# Altium schematic sheet CPU - POWER.SchDoc
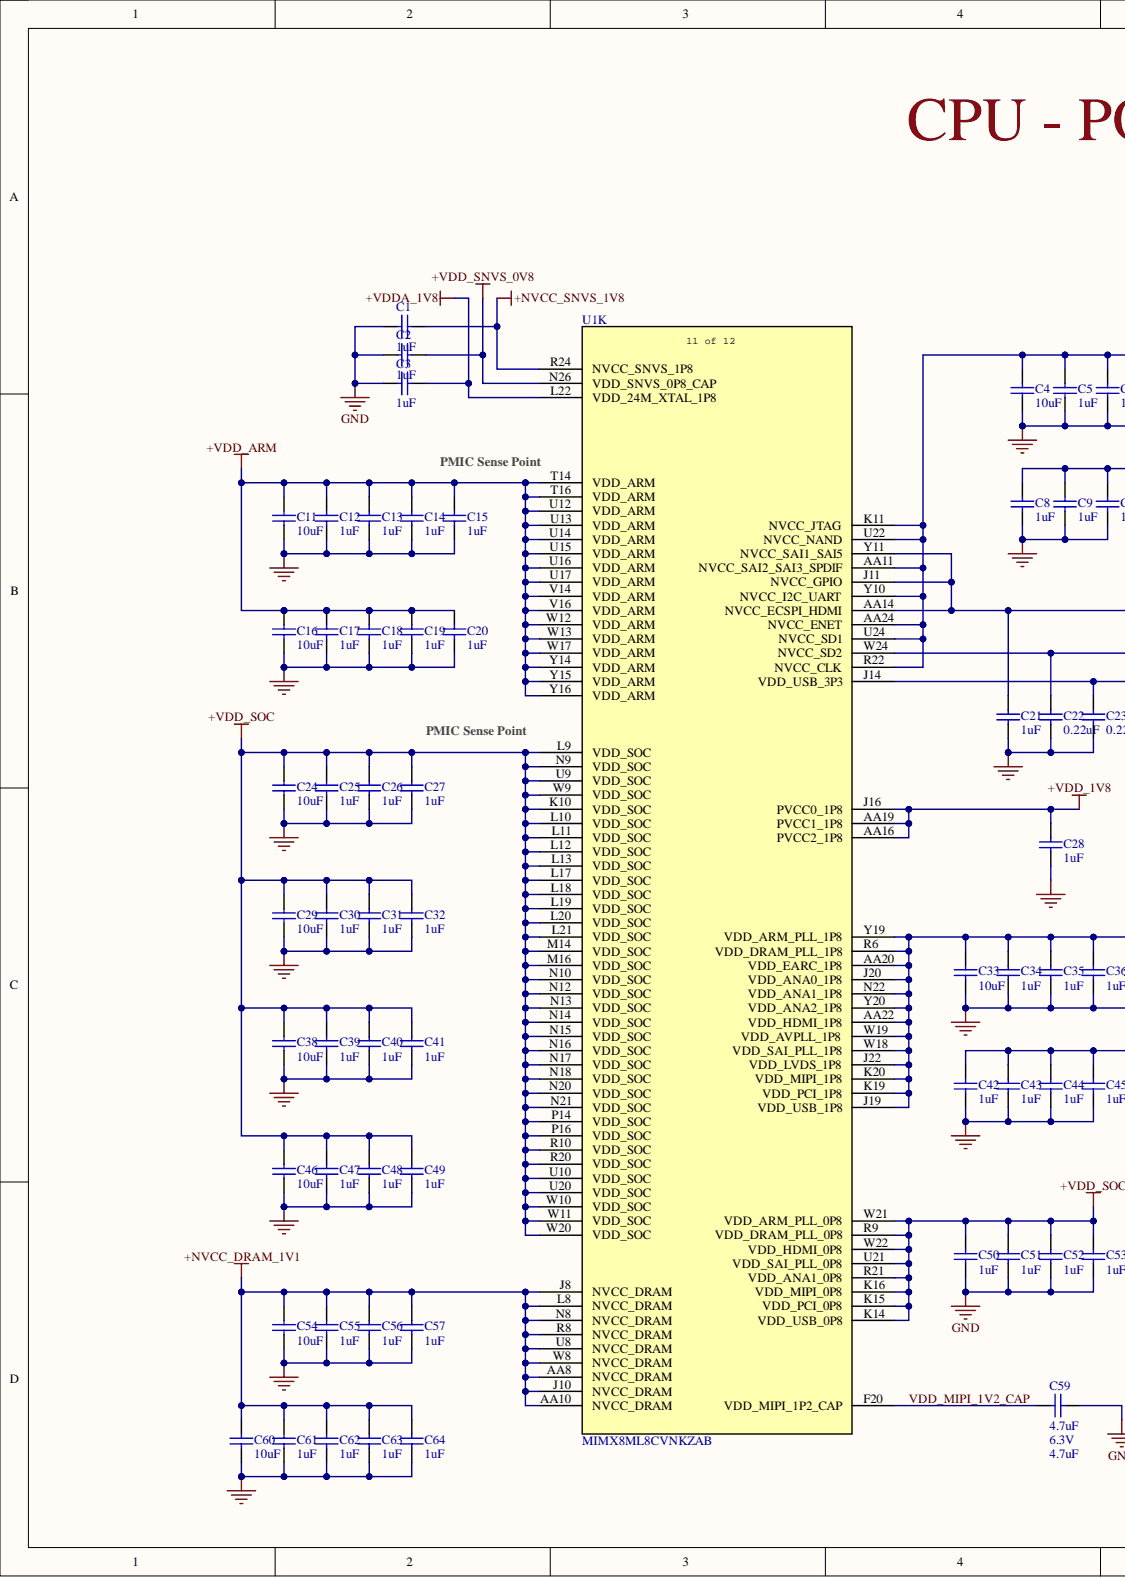
[diagram p0: CPU - POWER.SchDoc, left]
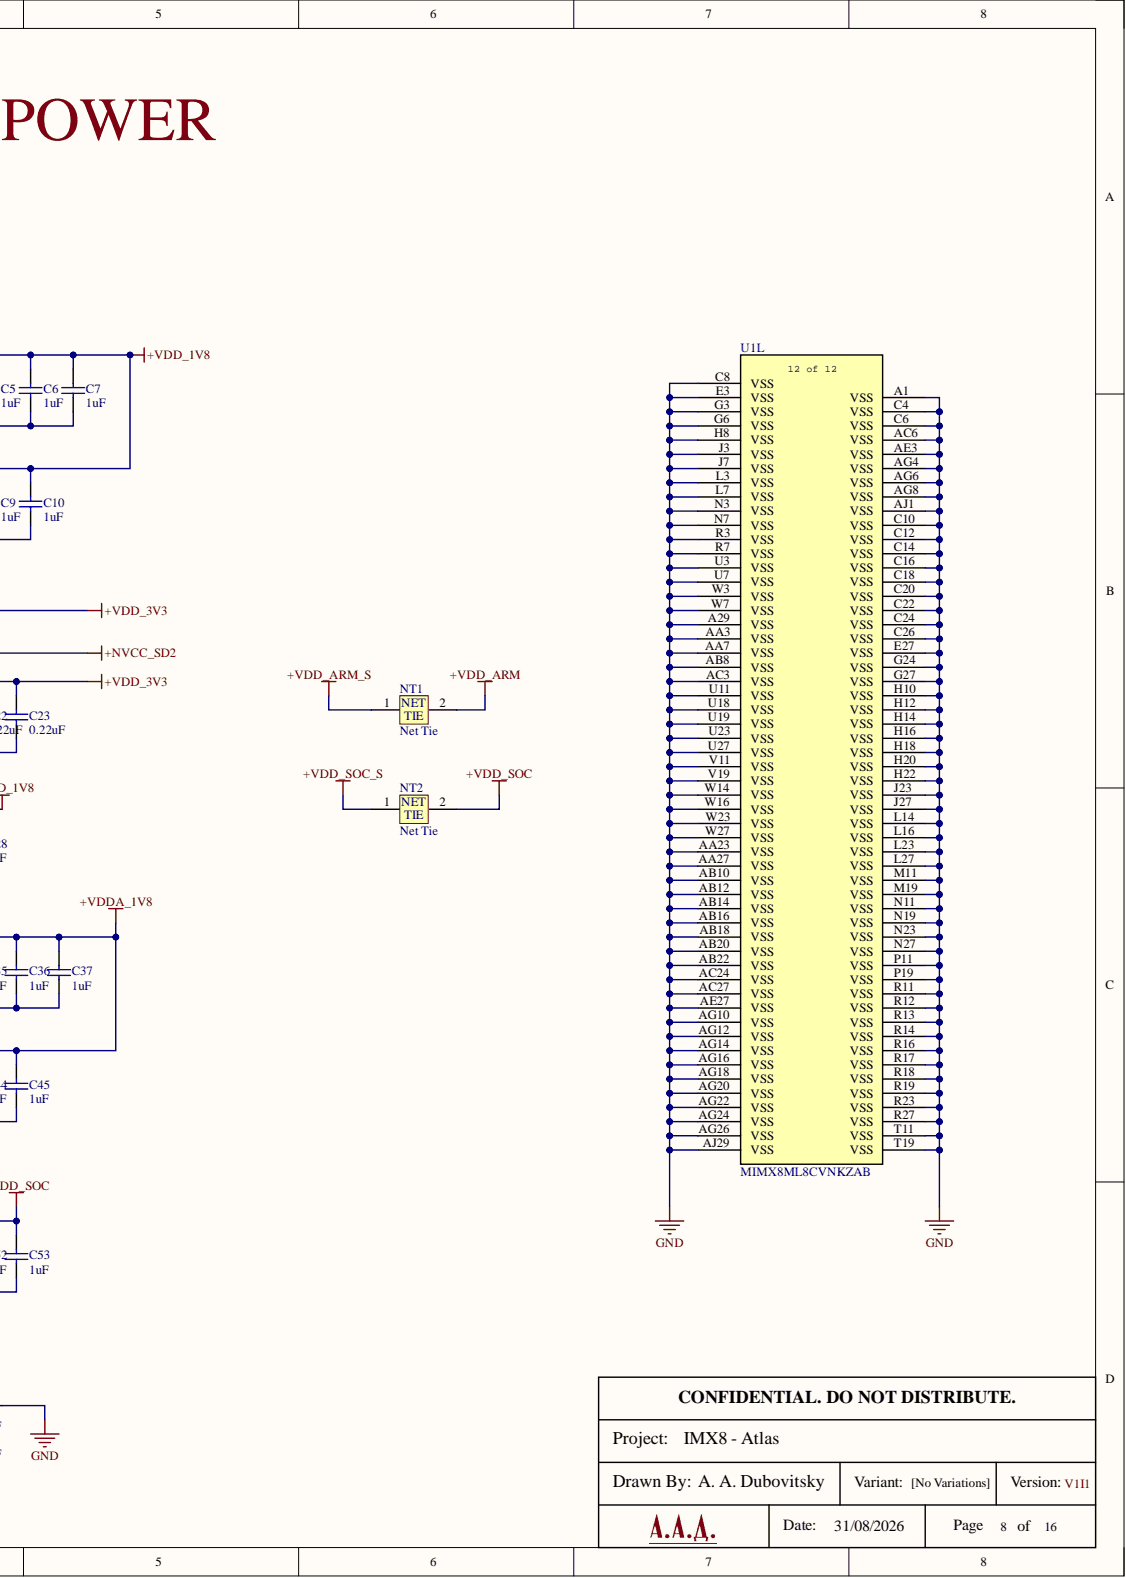
[diagram p1: CPU - POWER.SchDoc, right]

## Parts
C1  1uF  [CAP 0201_0603 MINI]
C2  1uF  [CAP 0201_0603 MINI]
C3  1uF  [CAP 0201_0603 MINI]
C4  10uF  [CAP 0402_1005]
C5  1uF  [CAP 0201_0603 MINI]
C6  1uF  [CAP 0201_0603 MINI]
C7  1uF  [CAP 0201_0603 MINI]
C8  1uF  [CAP 0201_0603 MINI]
C9  1uF  [CAP 0201_0603 MINI]
C10  1uF  [CAP 0201_0603 MINI]
C11  10uF  [CAP 0402_1005]
C12  1uF  [CAP 0201_0603 MINI]
C13  1uF  [CAP 0201_0603 MINI]
C14  1uF  [CAP 0201_0603 MINI]
C15  1uF  [CAP 0201_0603 MINI]
C16  10uF  [CAP 0402_1005]
C17  1uF  [CAP 0201_0603 MINI]
C18  1uF  [CAP 0201_0603 MINI]
C19  1uF  [CAP 0201_0603 MINI]
C20  1uF  [CAP 0201_0603 MINI]
C21  1uF  [CAP 0201_0603 MINI]
C22  0.22uF  [CAP 0201_0603 MINI]
C23  0.22uF  [CAP 0201_0603 MINI]
C24  10uF  [CAP 0402_1005]
C25  1uF  [CAP 0201_0603 MINI]
C26  1uF  [CAP 0201_0603 MINI]
C27  1uF  [CAP 0201_0603 MINI]
C28  1uF  [CAP 0201_0603 MINI]
C29  10uF  [CAP 0402_1005]
C30  1uF  [CAP 0201_0603 MINI]
C31  1uF  [CAP 0201_0603 MINI]
C32  1uF  [CAP 0201_0603 MINI]
C33  10uF  [CAP 0402_1005]
C34  1uF  [CAP 0201_0603 MINI]
C35  1uF  [CAP 0201_0603 MINI]
C36  1uF  [CAP 0201_0603 MINI]
C37  1uF  [CAP 0201_0603 MINI]
C38  10uF  [CAP 0402_1005]
C39  1uF  [CAP 0201_0603 MINI]
C40  1uF  [CAP 0201_0603 MINI]
C41  1uF  [CAP 0201_0603 MINI]
C42  1uF  [CAP 0201_0603 MINI]
C43  1uF  [CAP 0201_0603 MINI]
C44  1uF  [CAP 0201_0603 MINI]
C45  1uF  [CAP 0201_0603 MINI]
C46  10uF  [CAP 0402_1005]
C47  1uF  [CAP 0201_0603 MINI]
C48  1uF  [CAP 0201_0603 MINI]
C49  1uF  [CAP 0201_0603 MINI]
C50  1uF  [CAP 0201_0603 MINI]
C51  1uF  [CAP 0201_0603 MINI]
C52  1uF  [CAP 0201_0603 MINI]
C53  1uF  [CAP 0201_0603 MINI]
C54  10uF  [CAP 0402_1005]
C55  1uF  [CAP 0201_0603 MINI]
C56  1uF  [CAP 0201_0603 MINI]
C57  1uF  [CAP 0201_0603 MINI]
C59  4.7uF  V: 6.3V  [CAP 0402_1005]
C60  10uF  [CAP 0402_1005]
C61  1uF  [CAP 0201_0603 MINI]
C62  1uF  [CAP 0201_0603 MINI]
C63  1uF  [CAP 0201_0603 MINI]
C64  1uF  [CAP 0201_0603 MINI]
NT1  Net Tie  [Net Tie]
NT2  Net Tie  [Net Tie]
U1  MIMX8ML8CVNKZAB  [BGA548C50P29X29_1500X1500X148]  (parts K,L)

## Nets
+NVCC_DRAM_1V1: C54.2 C55.2 C56.2 C57.2 C60.2 C61.2 C62.2 C63.2 C64.2 U1.AA8(NVCC_DRAM) U1.AA10(NVCC_DRAM) U1.J8(NVCC_DRAM) U1.J10(NVCC_DRAM) U1.L8(NVCC_DRAM) U1.N8(NVCC_DRAM) U1.R8(NVCC_DRAM) U1.U8(NVCC_DRAM) U1.W8(NVCC_DRAM)
+NVCC_SD2: C22.2 U1.W24(NVCC_SD2)
+NVCC_SNVS_1V8: C1.1 U1.R24(NVCC_SNVS_1P8)
+VDD_1V8: C4.2 C5.2 C6.2 C7.2 C8.2 C9.2 C10.2 C28.2 U1.AA11(NVCC_SAI2_SAI3_SPDIF) U1.AA16(PVCC2_1P8) U1.AA19(PVCC1_1P8) U1.AA24(NVCC_ENET) U1.J16(PVCC0_1P8) U1.K11(NVCC_JTAG) U1.R22(NVCC_CLK) U1.U22(NVCC_NAND) U1.U24(NVCC_SD1) U1.Y10(NVCC_I2C_UART)
+VDD_3V3: C21.2 C23.2 U1.AA14(NVCC_ECSPI_HDMI) U1.J11(NVCC_GPIO) U1.J14(VDD_USB_3P3) U1.Y11(NVCC_SAI1_SAI5)
+VDD_ARM: C11.2 C12.2 C13.2 C14.2 C15.2 C16.2 C17.2 C18.2 C19.2 C20.2 NT1.2 U1.T14(VDD_ARM) U1.T16(VDD_ARM) U1.U12(VDD_ARM) U1.U13(VDD_ARM) U1.U14(VDD_ARM) U1.U15(VDD_ARM) U1.U16(VDD_ARM) U1.U17(VDD_ARM) U1.V14(VDD_ARM) U1.V16(VDD_ARM) U1.W12(VDD_ARM) U1.W13(VDD_ARM) U1.W17(VDD_ARM) U1.Y14(VDD_ARM) U1.Y15(VDD_ARM) U1.Y16(VDD_ARM)
+VDD_ARM_S: NT1.1
+VDD_SNVS_0V8: C2.1 U1.N26(VDD_SNVS_0P8_CAP)
+VDD_SOC: C24.2 C25.2 C26.2 C27.2 C29.2 C30.2 C31.2 C32.2 C38.2 C39.2 C40.2 C41.2 C46.2 C47.2 C48.2 C49.2 C50.2 C51.2 C52.2 C53.2 NT2.2 U1.K10(VDD_SOC) U1.K14(VDD_USB_0P8) U1.K15(VDD_PCI_0P8) U1.K16(VDD_MIPI_0P8) U1.L9(VDD_SOC) U1.L10(VDD_SOC) U1.L11(VDD_SOC) U1.L12(VDD_SOC) U1.L13(VDD_SOC) U1.L17(VDD_SOC) U1.L18(VDD_SOC) U1.L19(VDD_SOC) U1.L20(VDD_SOC) U1.L21(VDD_SOC) U1.M14(VDD_SOC) U1.M16(VDD_SOC) U1.N9(VDD_SOC) U1.N10(VDD_SOC) U1.N12(VDD_SOC) U1.N13(VDD_SOC) U1.N14(VDD_SOC) U1.N15(VDD_SOC) U1.N16(VDD_SOC) U1.N17(VDD_SOC) U1.N18(VDD_SOC) U1.N20(VDD_SOC) U1.N21(VDD_SOC) U1.P14(VDD_SOC) U1.P16(VDD_SOC) U1.R9(VDD_DRAM_PLL_0P8) U1.R10(VDD_SOC) U1.R20(VDD_SOC) U1.R21(VDD_ANA1_0P8) U1.U9(VDD_SOC) U1.U10(VDD_SOC) U1.U20(VDD_SOC) U1.U21(VDD_SAI_PLL_0P8) U1.W9(VDD_SOC) U1.W10(VDD_SOC) U1.W11(VDD_SOC) U1.W20(VDD_SOC) U1.W21(VDD_ARM_PLL_0P8) U1.W22(VDD_HDMI_0P8)
+VDD_SOC_S: NT2.1
+VDDA_1V8: C3.1 C33.2 C34.2 C35.2 C36.2 C37.2 C42.2 C43.2 C44.2 C45.2 U1.AA20(VDD_EARC_1P8) U1.AA22(VDD_HDMI_1P8) U1.J19(VDD_USB_1P8) U1.J20(VDD_ANA0_1P8) U1.J22(VDD_LVDS_1P8) U1.K19(VDD_PCI_1P8) U1.K20(VDD_MIPI_1P8) U1.L22(VDD_24M_XTAL_1P8) U1.N22(VDD_ANA1_1P8) U1.R6(VDD_DRAM_PLL_1P8) U1.W18(VDD_SAI_PLL_1P8) U1.W19(VDD_AVPLL_1P8) U1.Y19(VDD_ARM_PLL_1P8) U1.Y20(VDD_ANA2_1P8)
GND: C1.2 C2.2 C3.2 C4.1 C5.1 C6.1 C7.1 C8.1 C9.1 C10.1 C11.1 C12.1 C13.1 C14.1 C15.1 C16.1 C17.1 C18.1 C19.1 C20.1 C21.1 C22.1 C23.1 C24.1 C25.1 C26.1 C27.1 C28.1 C29.1 C30.1 C31.1 C32.1 C33.1 C34.1 C35.1 C36.1 C37.1 C38.1 C39.1 C40.1 C41.1 C42.1 C43.1 C44.1 C45.1 C46.1 C47.1 C48.1 C49.1 C50.1 C51.1 C52.1 C53.1 C54.1 C55.1 C56.1 C57.1 C59.2 C60.1 C61.1 C62.1 C63.1 C64.1 U1.A1(VSS) U1.A29(VSS) U1.AA3(VSS) U1.AA7(VSS) U1.AA23(VSS) U1.AA27(VSS) U1.AB8(VSS) U1.AB10(VSS) U1.AB12(VSS) U1.AB14(VSS) U1.AB16(VSS) U1.AB18(VSS) U1.AB20(VSS) U1.AB22(VSS) U1.AC3(VSS) U1.AC6(VSS) U1.AC24(VSS) U1.AC27(VSS) U1.AE3(VSS) U1.AE27(VSS) U1.AG4(VSS) U1.AG6(VSS) U1.AG8(VSS) U1.AG10(VSS) U1.AG12(VSS) U1.AG14(VSS) U1.AG16(VSS) U1.AG18(VSS) U1.AG20(VSS) U1.AG22(VSS) U1.AG24(VSS) U1.AG26(VSS) U1.AJ1(VSS) U1.AJ29(VSS) U1.C4(VSS) U1.C6(VSS) U1.C8(VSS) U1.C10(VSS) U1.C12(VSS) U1.C14(VSS) U1.C16(VSS) U1.C18(VSS) U1.C20(VSS) U1.C22(VSS) U1.C24(VSS) U1.C26(VSS) U1.E3(VSS) U1.E27(VSS) U1.G3(VSS) U1.G6(VSS) U1.G24(VSS) U1.G27(VSS) U1.H8(VSS) U1.H10(VSS) U1.H12(VSS) U1.H14(VSS) U1.H16(VSS) U1.H18(VSS) U1.H20(VSS) U1.H22(VSS) U1.J3(VSS) U1.J7(VSS) U1.J23(VSS) U1.J27(VSS) U1.L3(VSS) U1.L7(VSS) U1.L14(VSS) U1.L16(VSS) U1.L23(VSS) U1.L27(VSS) U1.M11(VSS) U1.M19(VSS) U1.N3(VSS) U1.N7(VSS) U1.N11(VSS) U1.N19(VSS) U1.N23(VSS) U1.N27(VSS) U1.P11(VSS) U1.P19(VSS) U1.R3(VSS) U1.R7(VSS) U1.R11(VSS) U1.R12(VSS) U1.R13(VSS) U1.R14(VSS) U1.R16(VSS) U1.R17(VSS) U1.R18(VSS) U1.R19(VSS) U1.R23(VSS) U1.R27(VSS) U1.T11(VSS) U1.T19(VSS) U1.U3(VSS) U1.U7(VSS) U1.U11(VSS) U1.U18(VSS) U1.U19(VSS) U1.U23(VSS) U1.U27(VSS) U1.V11(VSS) U1.V19(VSS) U1.W3(VSS) U1.W7(VSS) U1.W14(VSS) U1.W16(VSS) U1.W23(VSS) U1.W27(VSS)
VDD_MIPI_1V2_CAP: C59.1 U1.F20(VDD_MIPI_1P2_CAP)

## Library data of the parts
C1-C3 C5-C10 C12-C15 C17-C21 C25-C28 C30-C32 C34-C37 C39-C45 C47-C53 C55-C57 C61-C64 = C6119758: Cap. 1uF 10V ±20% 0201; Component Kind: Standard; Library Name: Atlas - Symbols.SchLib
C4 C11 C16 C24 C29 C33 C38 C46 C54 C60 = C2846677: Cap. 10uF 10V ±20% 0402; Component Kind: Standard; Library Name: Atlas - Symbols.SchLib
C22 C23 = C76932: Cap. 0.22uF 6.3V ±20% 0201; Component Kind: Standard; Library Name: Atlas - Symbols.SchLib
C59 = GRM155R60J475ME87D: Cap. 4.7uF 6.3V ±20%; C: 4.7uF; Component Kind: Standard; Library Name: Atlas - Symbols.SchLib
U1 = MIMX8ML8CVNKZAB: IC ARM MCU; Manufacturer_Name: NXP; Component Kind: Standard; Manufacturer_Part_Number: MIMX8ML8CVNKZAB; RefDes: RefDes; Type: Type; Copyright: Copyright (C) 2026 Ultra Librarian. All rights reserved.; Library Name: Atlas - Symbols.SchLib
NT1 NT2 = Net Tie: Component Kind: Net Tie; Library Name: Atlas - Symbols.SchLib
